# Altium schematic sheet ADC and sensors.SchDoc
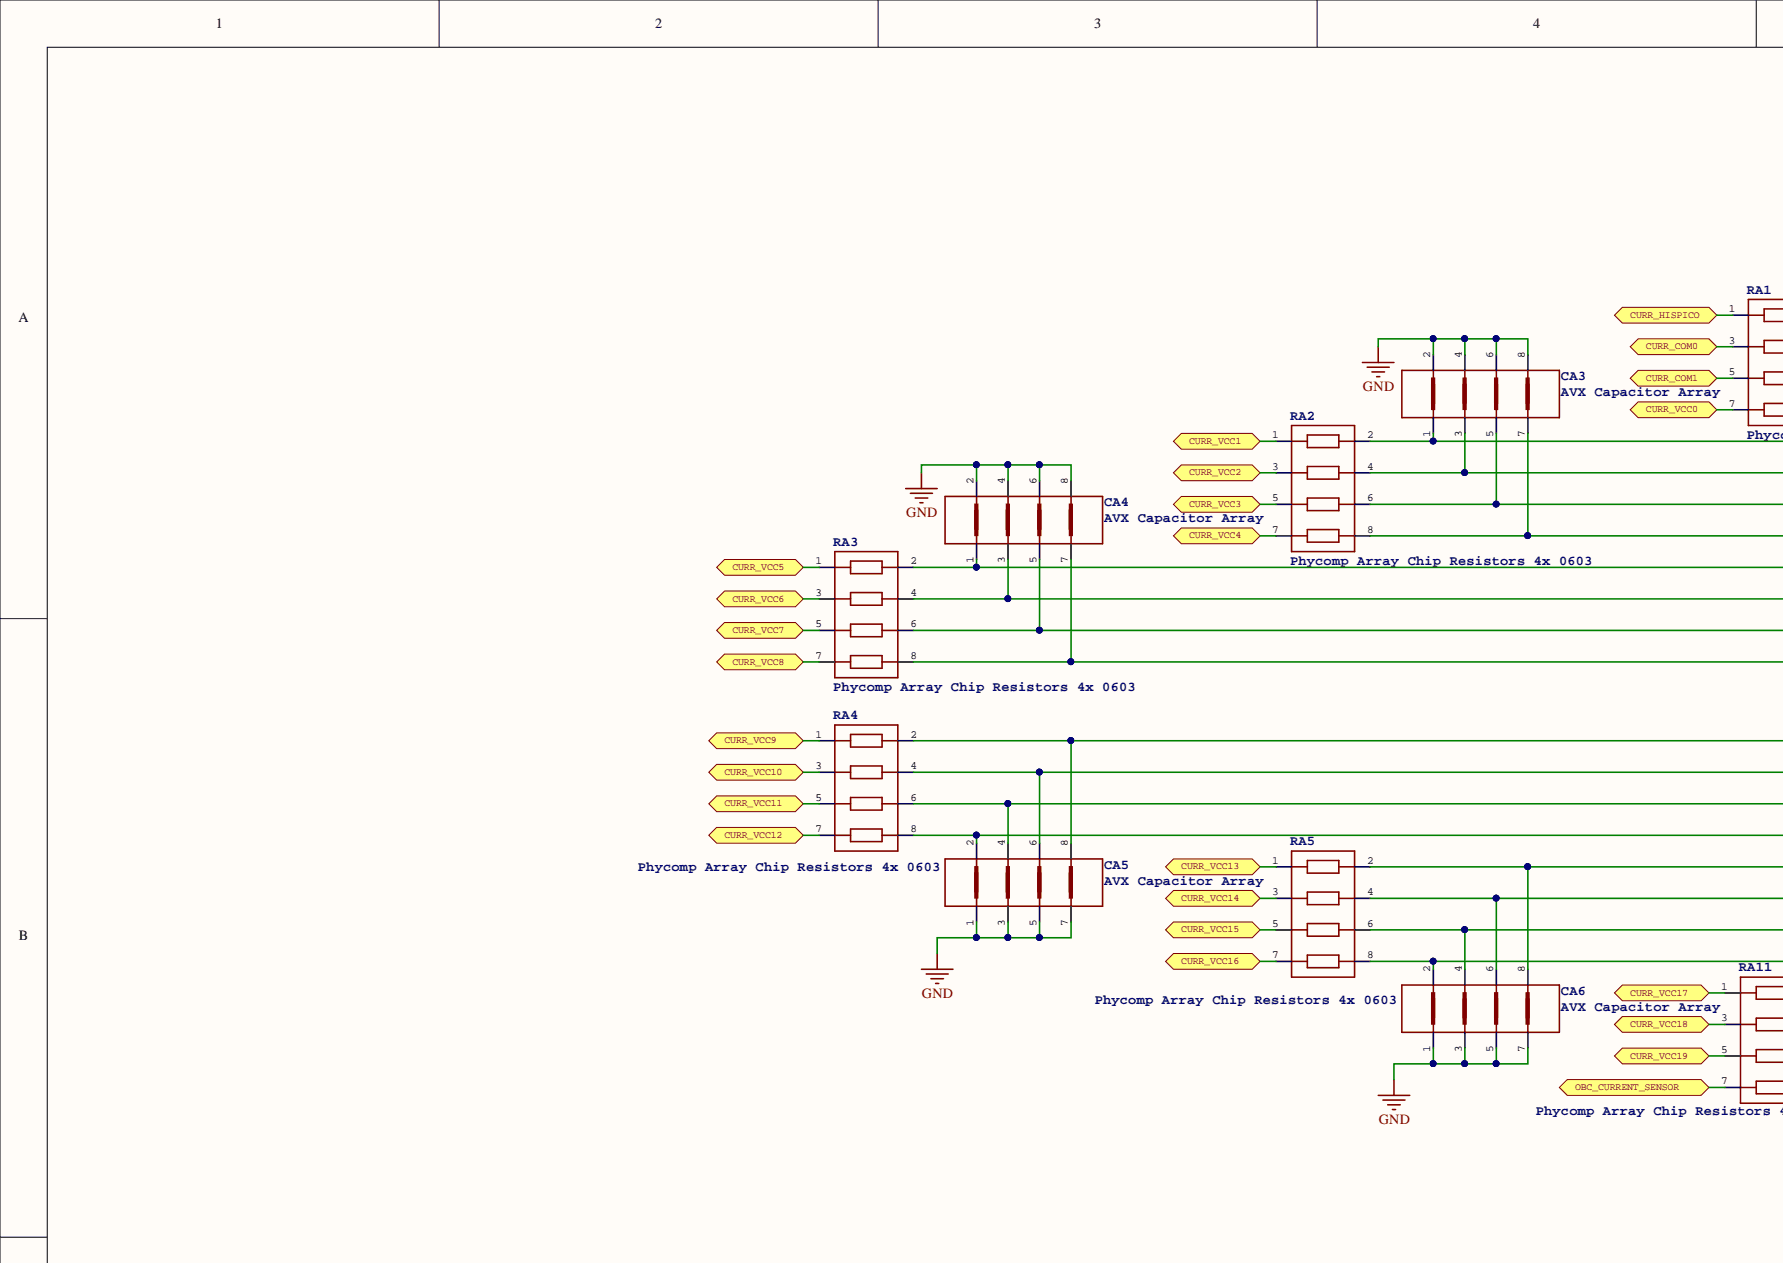
[diagram p0: ADC and sensors.SchDoc, top left]
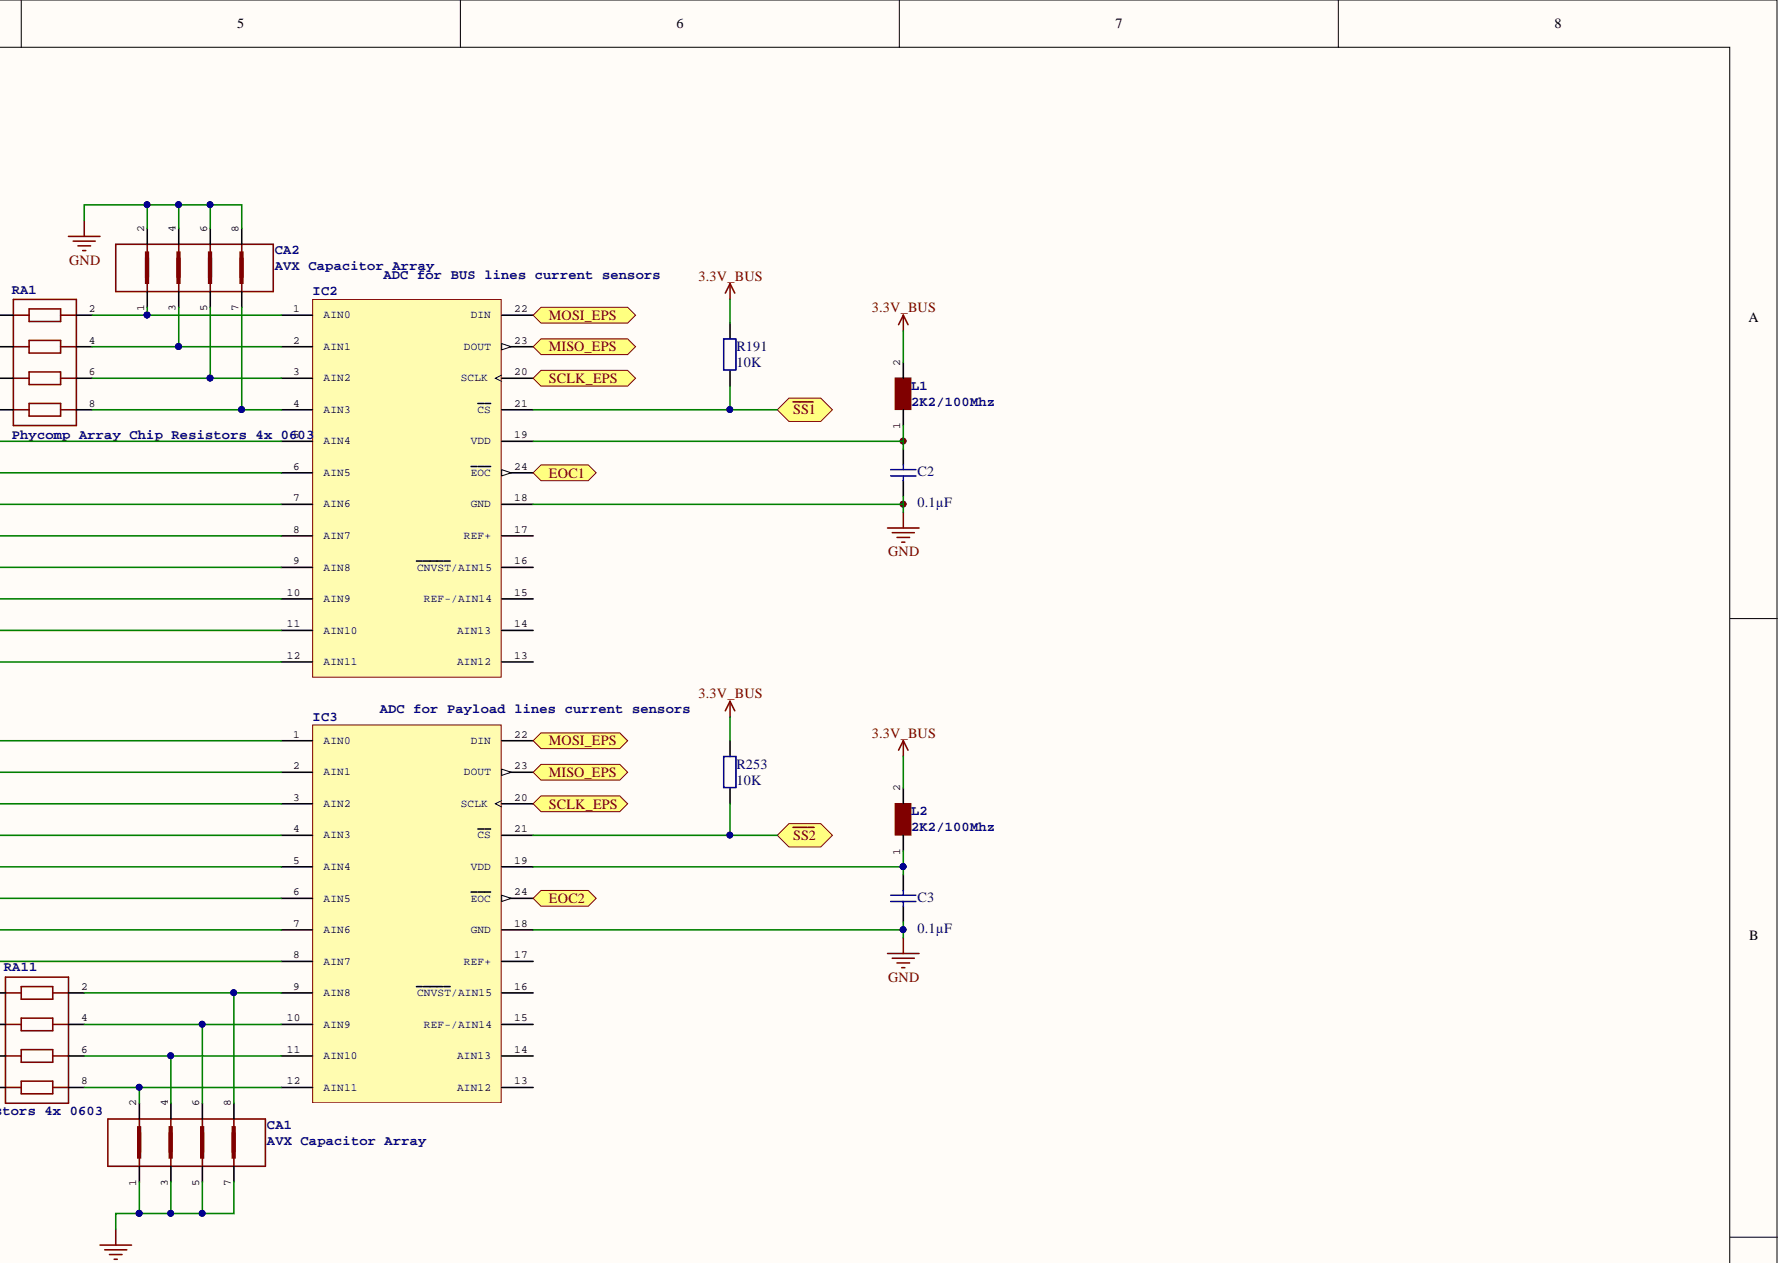
[diagram p1: ADC and sensors.SchDoc, top right]
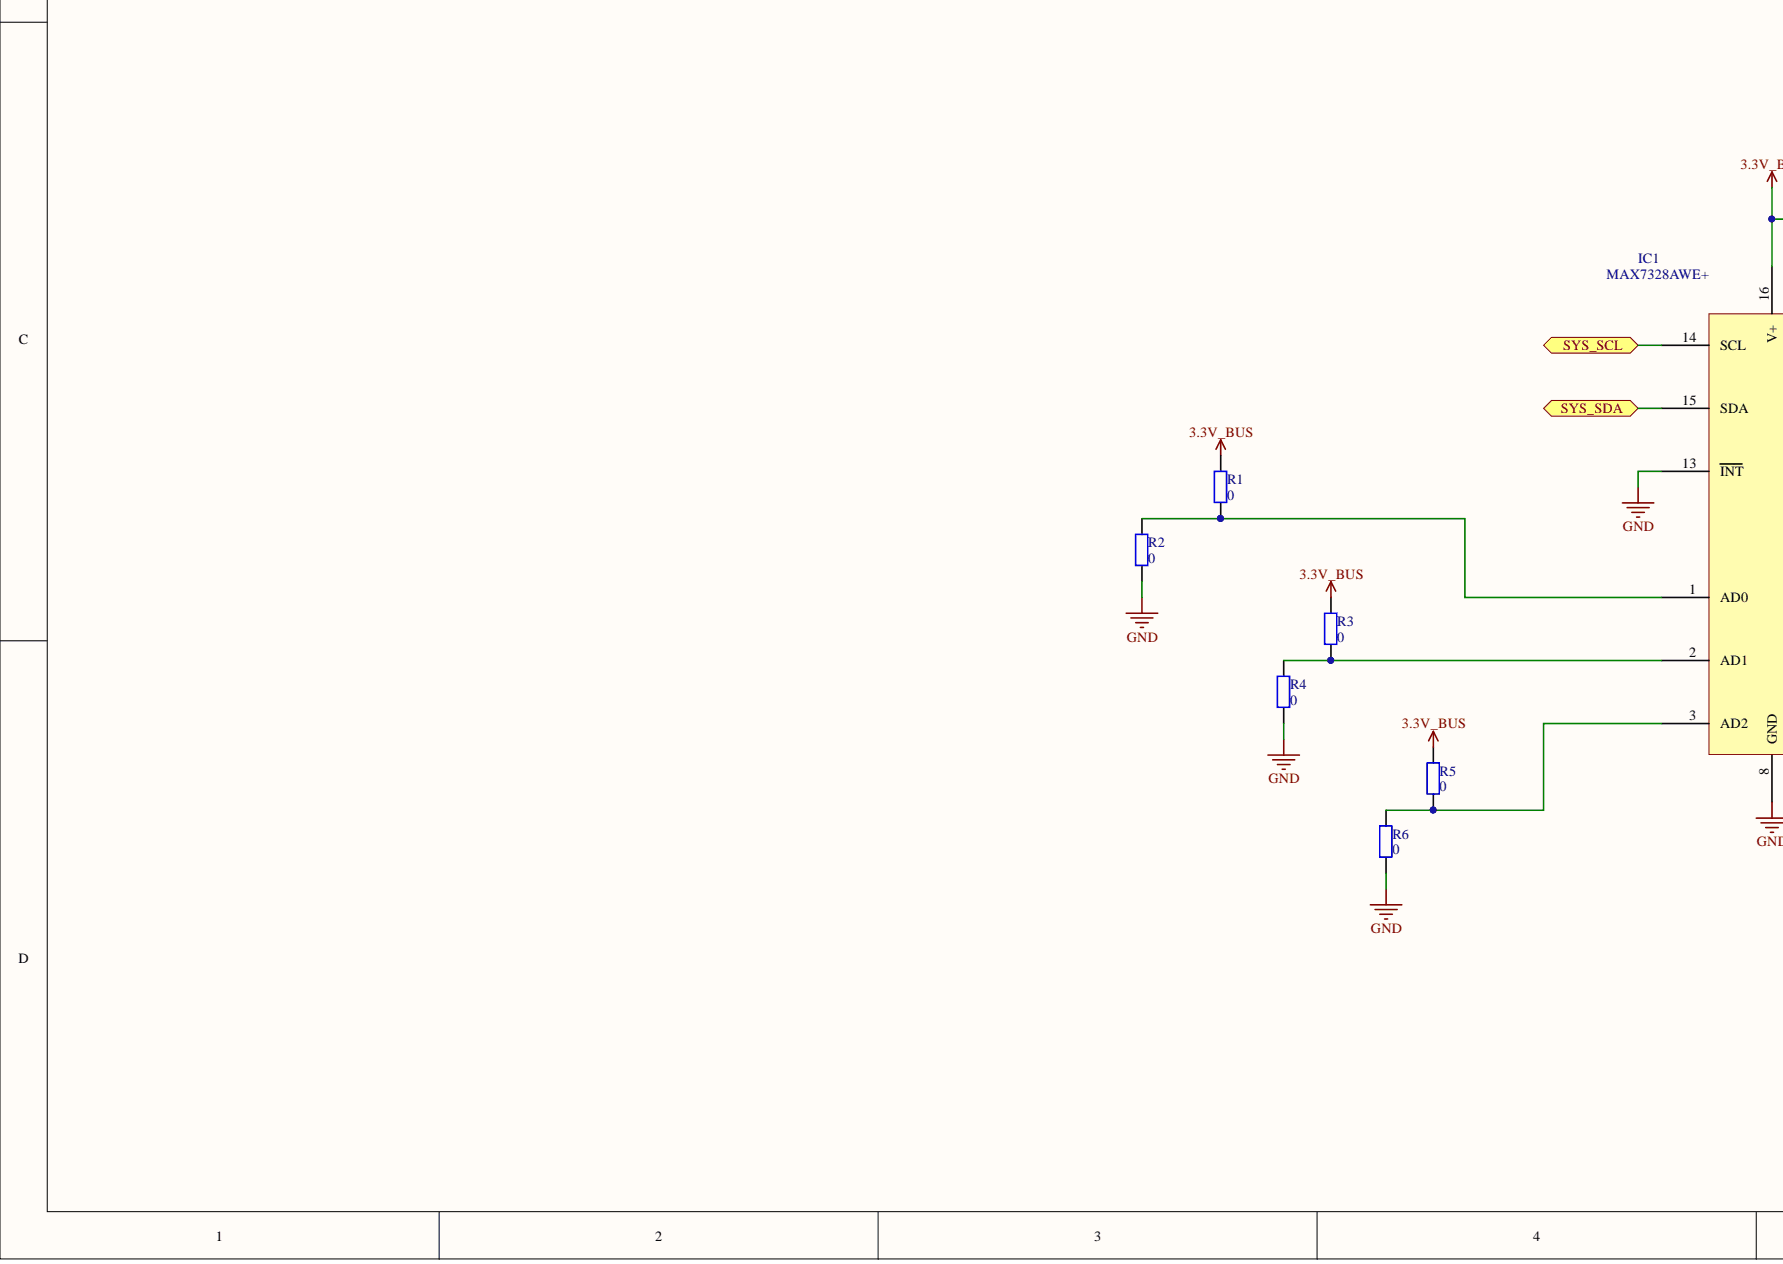
[diagram p2: ADC and sensors.SchDoc, bottom left]
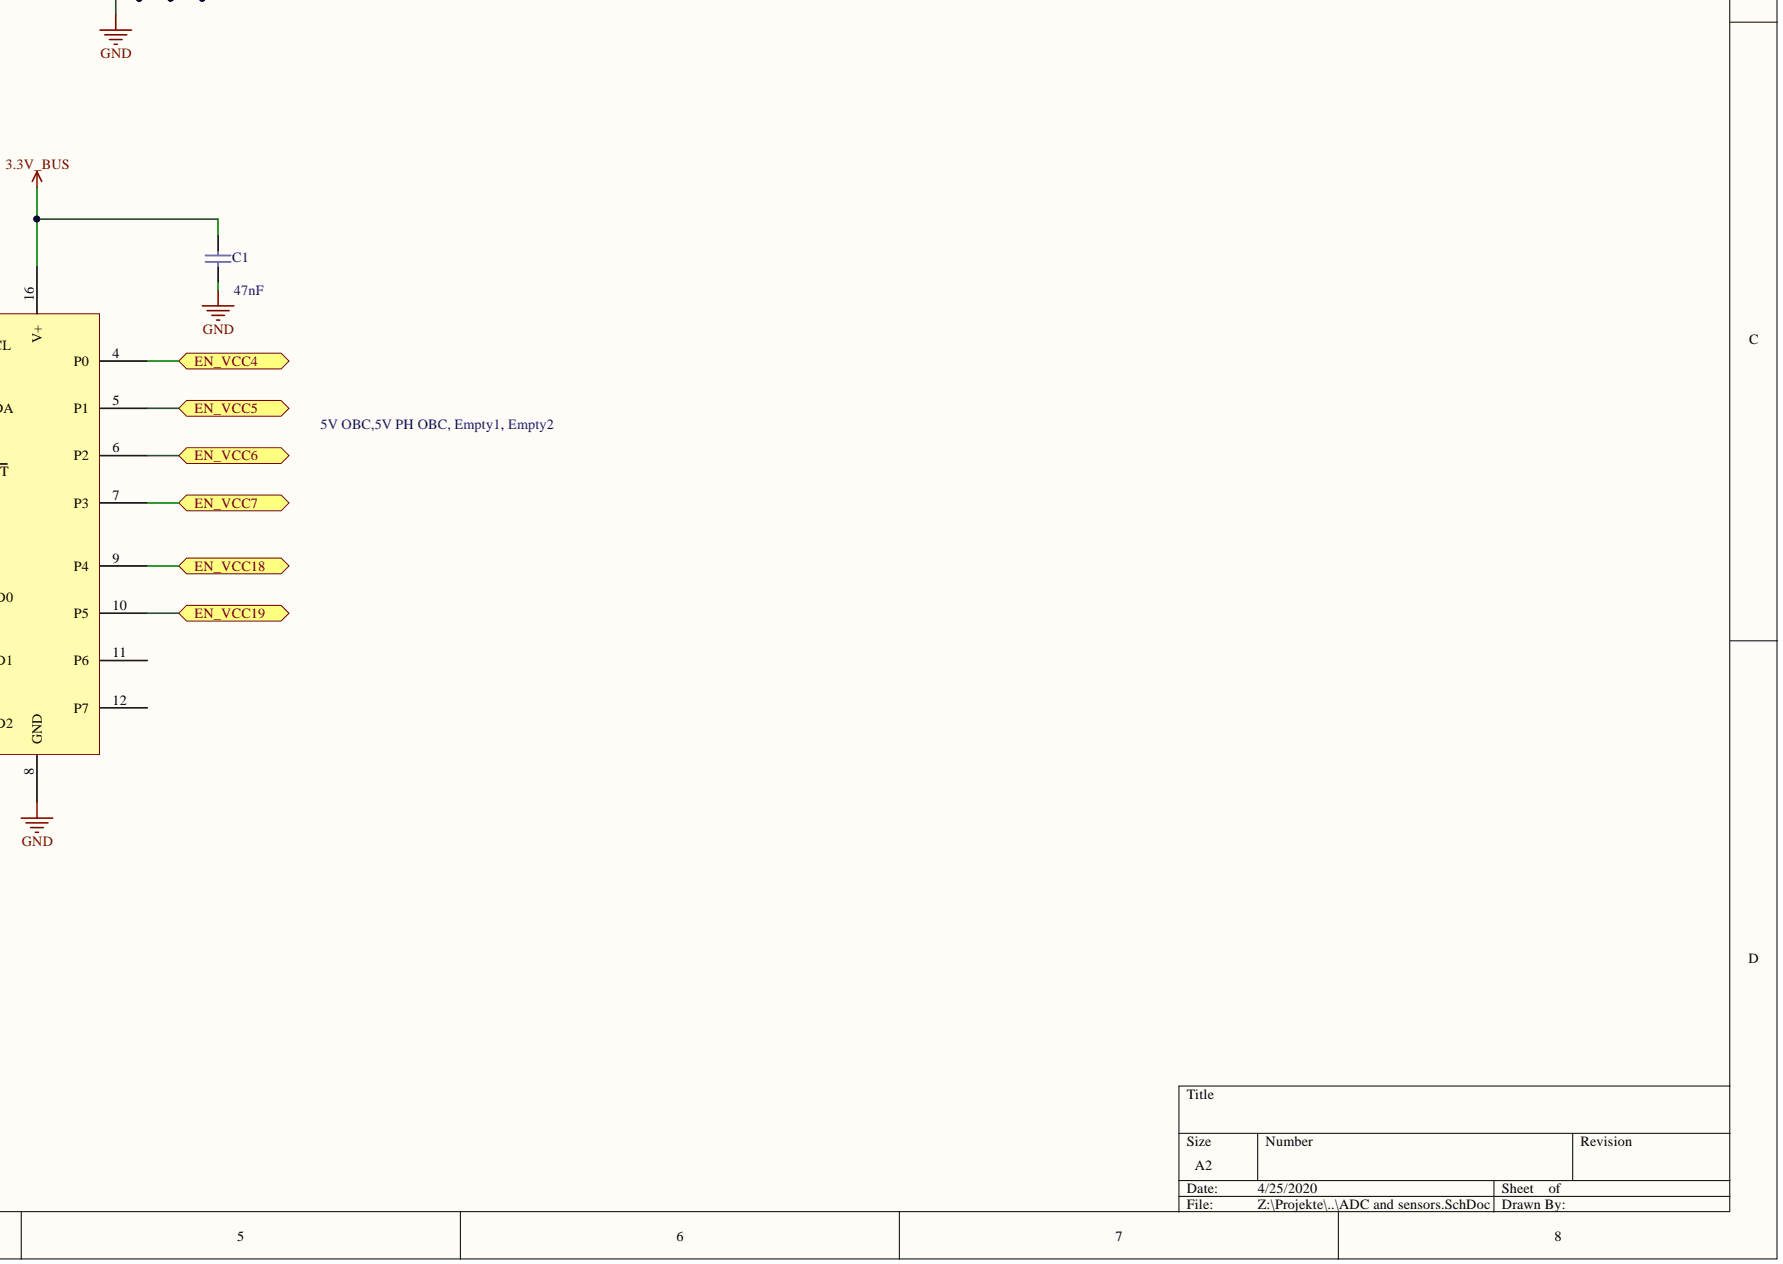
[diagram p3: ADC and sensors.SchDoc, bottom right]

## Parts
C1  47nF  [1608[0603]]
C2  0.1µF  [1608[0603]]
C3  0.1µF  [1608[0603]]
CA1  AVX Capacitor Array  [0612]
CA2  AVX Capacitor Array  [0612]
CA3  AVX Capacitor Array  [0612]
CA4  AVX Capacitor Array  [0612]
CA5  AVX Capacitor Array  [0612]
CA6  AVX Capacitor Array  [0612]
IC1  MAX7328AWE+  [SOIC127P1030X265-16N]
IC2  ADC for BUS lines current sensors  [QSOP24]
IC3  ADC for Payload lines current sensors  [QSOP24]
L1  2K2/100Mhz  [0603]
L2  2K2/100Mhz  [0603]
R1  value 0  [1608[0603]]  (hidden comment: 0 n.p.)
R2  0  [1608[0603]]
R3  value 0  [1608[0603]]  (hidden comment: 0 n.p.)
R4  0  [1608[0603]]
R5  value 0  [1608[0603]]  (hidden comment: 0 n.p.)
R6  0  [1608[0603]]
R191  10K  [1608[0603]]
R253  10K  [1608[0603]]
RA1  Phycomp Array Chip Resistors 4x 0603  [0612_ARC]
RA2  Phycomp Array Chip Resistors 4x 0603  [0612_ARC]
RA3  Phycomp Array Chip Resistors 4x 0603  [0612_ARC]
RA4  Phycomp Array Chip Resistors 4x 0603  [0612_ARC]
RA5  Phycomp Array Chip Resistors 4x 0603  [0612_ARC]
RA11  Phycomp Array Chip Resistors 4x 0603  [0612_ARC]

## Ports
CURR_COM0, CURR_COM1, CURR_HISPICO, CURR_VCC0, CURR_VCC1, CURR_VCC2, CURR_VCC3, CURR_VCC4, CURR_VCC5, CURR_VCC6, CURR_VCC7, CURR_VCC8, CURR_VCC9, CURR_VCC10, CURR_VCC11, CURR_VCC12, CURR_VCC13, CURR_VCC14, CURR_VCC15, CURR_VCC16, CURR_VCC17, CURR_VCC18, CURR_VCC19, EN_VCC4, EN_VCC5, EN_VCC6, EN_VCC7, EN_VCC18, EN_VCC19, EOC1, EOC2, MISO_EPS, MOSI_EPS, OBC_CURRENT_SENSOR, SCLK_EPS, SYS_SCL, SYS_SDA, ~{SS1}, ~{SS2}

## Nets
3.3V_BUS: C1.2 IC1.16(V+) L1.2 L2.2 R1.1 R3.1 R5.1 R191.1 R253.1
GND: C1.1 C2.1 C3.1 CA1.1 CA1.3 CA1.5 CA1.7 CA2.2 CA2.4 CA2.6 CA2.8 CA3.2 CA3.4 CA3.6 CA3.8 CA4.2 CA4.4 CA4.6 CA4.8 CA5.1 CA5.3 CA5.5 CA5.7 CA6.1 CA6.3 CA6.5 CA6.7 IC1.8(GND) IC1.13(INT) IC2.18(GND) IC3.18(GND) R2.2 R4.2 R6.2
CURR_COM0: RA1.3 ; port CURR_COM0
CURR_COM1: RA1.5 ; port CURR_COM1
CURR_HISPICO: RA1.1 ; port CURR_HISPICO
CURR_VCC0: RA1.7 ; port CURR_VCC0
CURR_VCC1: RA2.1 ; port CURR_VCC1
CURR_VCC2: RA2.3 ; port CURR_VCC2
CURR_VCC3: RA2.5 ; port CURR_VCC3
CURR_VCC4: RA2.7 ; port CURR_VCC4
CURR_VCC5: RA3.1 ; port CURR_VCC5
CURR_VCC6: RA3.3 ; port CURR_VCC6
CURR_VCC7: RA3.5 ; port CURR_VCC7
CURR_VCC8: RA3.7 ; port CURR_VCC8
CURR_VCC9: RA4.1 ; port CURR_VCC9
CURR_VCC10: RA4.3 ; port CURR_VCC10
CURR_VCC11: RA4.5 ; port CURR_VCC11
CURR_VCC12: RA4.7 ; port CURR_VCC12
CURR_VCC13: RA5.1 ; port CURR_VCC13
CURR_VCC14: RA5.3 ; port CURR_VCC14
CURR_VCC15: RA5.5 ; port CURR_VCC15
CURR_VCC16: RA5.7 ; port CURR_VCC16
CURR_VCC17: RA11.1 ; port CURR_VCC17
CURR_VCC18: RA11.3 ; port CURR_VCC18
CURR_VCC19: RA11.5 ; port CURR_VCC19
EN_VCC4: IC1.4(P0) ; port EN_VCC4
EN_VCC5: IC1.5(P1) ; port EN_VCC5
EN_VCC6: IC1.6(P2) ; port EN_VCC6
EN_VCC7: IC1.7(P3) ; port EN_VCC7
EN_VCC18: IC1.9(P4) ; port EN_VCC18
EN_VCC19: IC1.10(P5) ; port EN_VCC19
EOC1: IC2.24(EOC) ; port EOC1
EOC2: IC3.24(EOC) ; port EOC2
MISO_EPS: IC2.23(DOUT) IC3.23(DOUT) ; port MISO_EPS
MOSI_EPS: IC2.22(DIN) IC3.22(DIN) ; port MOSI_EPS
OBC_CURRENT_SENSOR: RA11.7 ; port OBC_CURRENT_SENSOR
~{SS1}: IC2.21(CS) R191.2 ; port ~{SS1}
~{SS2}: IC3.21(CS) R253.2 ; port ~{SS2}
SCLK_EPS: IC2.20(SCLK) IC3.20(SCLK) ; port SCLK_EPS
SYS_SCL: IC1.14(SCL) ; port SYS_SCL
SYS_SDA: IC1.15(SDA) ; port SYS_SDA
NetC2_2: C2.2 IC2.19(VDD) L1.1
NetC3_2: C3.2 IC3.19(VDD) L2.1
NetCA1_2: CA1.2 IC3.12(AIN11) RA11.8
NetCA1_4: CA1.4 IC3.11(AIN10) RA11.6
NetCA1_6: CA1.6 IC3.10(AIN9) RA11.4
NetCA1_8: CA1.8 IC3.9(AIN8) RA11.2
NetCA2_1: CA2.1 IC2.1(AIN0) RA1.2
NetCA2_3: CA2.3 IC2.2(AIN1) RA1.4
NetCA2_5: CA2.5 IC2.3(AIN2) RA1.6
NetCA2_7: CA2.7 IC2.4(AIN3) RA1.8
NetCA3_1: CA3.1 IC2.5(AIN4) RA2.2
NetCA3_3: CA3.3 IC2.6(AIN5) RA2.4
NetCA3_5: CA3.5 IC2.7(AIN6) RA2.6
NetCA3_7: CA3.7 IC2.8(AIN7) RA2.8
NetCA4_1: CA4.1 IC2.9(AIN8) RA3.2
NetCA4_3: CA4.3 IC2.10(AIN9) RA3.4
NetCA4_5: CA4.5 IC2.11(AIN10) RA3.6
NetCA4_7: CA4.7 IC2.12(AIN11) RA3.8
NetCA5_2: CA5.2 IC3.4(AIN3) RA4.8
NetCA5_4: CA5.4 IC3.3(AIN2) RA4.6
NetCA5_6: CA5.6 IC3.2(AIN1) RA4.4
NetCA5_8: CA5.8 IC3.1(AIN0) RA4.2
NetCA6_2: CA6.2 IC3.8(AIN7) RA5.8
NetCA6_4: CA6.4 IC3.7(AIN6) RA5.6
NetCA6_6: CA6.6 IC3.6(AIN5) RA5.4
NetCA6_8: CA6.8 IC3.5(AIN4) RA5.2
NetIC1_1: IC1.1(AD0) R1.2 R2.1
NetIC1_2: IC1.2(AD1) R3.2 R4.1
NetIC1_3: IC1.3(AD2) R5.2 R6.1

Not connected: IC1 11(P6) 12(P7); IC2 13(AIN12) 14(AIN13) 15(REF-/AIN14) 16(CNVST/AIN15) 17(REF+); IC3 13(AIN12) 14(AIN13) 15(REF-/AIN14) 16(CNVST/AIN15) 17(REF+)

## Library data of the parts
C1-C3 = Cap: Capacitor; Component Kind: Standard; LatestRevisionDate: 17-Jul-2002; LatestRevisionNote: Re-released for DXP Platform.; Library Name: Miscellaneous Devices.IntLib; PackageDescription: Radial Cap, Thru-Hole; 2 Leads; 0.3 in Pin Spacing; PackageReference: RAD-0.3; Published: 8-Jun-2000; Signal Integrity: Cap; Simulation: CAP; Publisher: Altium Limited
  C1: Value: 47nF
  C2 C3: Value: 0.1µF
CA1-CA6 = W?A43: Component Kind: Standard; Library Name: EPS_Pavel.SCHLIB
IC2 IC3 = MAX1231*?EG: Component Kind: Standard; Library Name: EPS_Pavel.SCHLIB
L1 L2 = WE-CBF_0603: SMD EMI Suppression Ferrite Beads - excellent anti-EMI properties - low DCR - impedances till 3000 ohms - rated current up to 6 A; Component Kind: Standard; Library Name: EPS.IntLib
R1-R6 R191 R253 = Res2: Resistor; Component Kind: Standard; LatestRevisionDate: 17-Jul-2002; LatestRevisionNote: Re-released for DXP Platform.; Library Name: Miscellaneous Devices.IntLib; PackageDescription: Axial Device, Thru-Hole; 2 Leads; 0.4 in Pin Spacing; PackageReference: AXIAL-0.4; Published: 8-Jun-2000; Signal Integrity: Res; Simulation: RESISTOR; Publisher: Altium Limited
  R2 R4 R6: Value: 0
  R191 R253: Value: 10K
RA1-RA5 RA11 = AR?24*C: Component Kind: Standard; Library Name: EPS_Pavel.SCHLIB
IC1 = MAX7328AWE+: Component Kind: Standard; Library Name: EPS_Pavel.SCHLIB
